# Altium schematic sheet Accelerometer.SchDoc(Accelerometer)
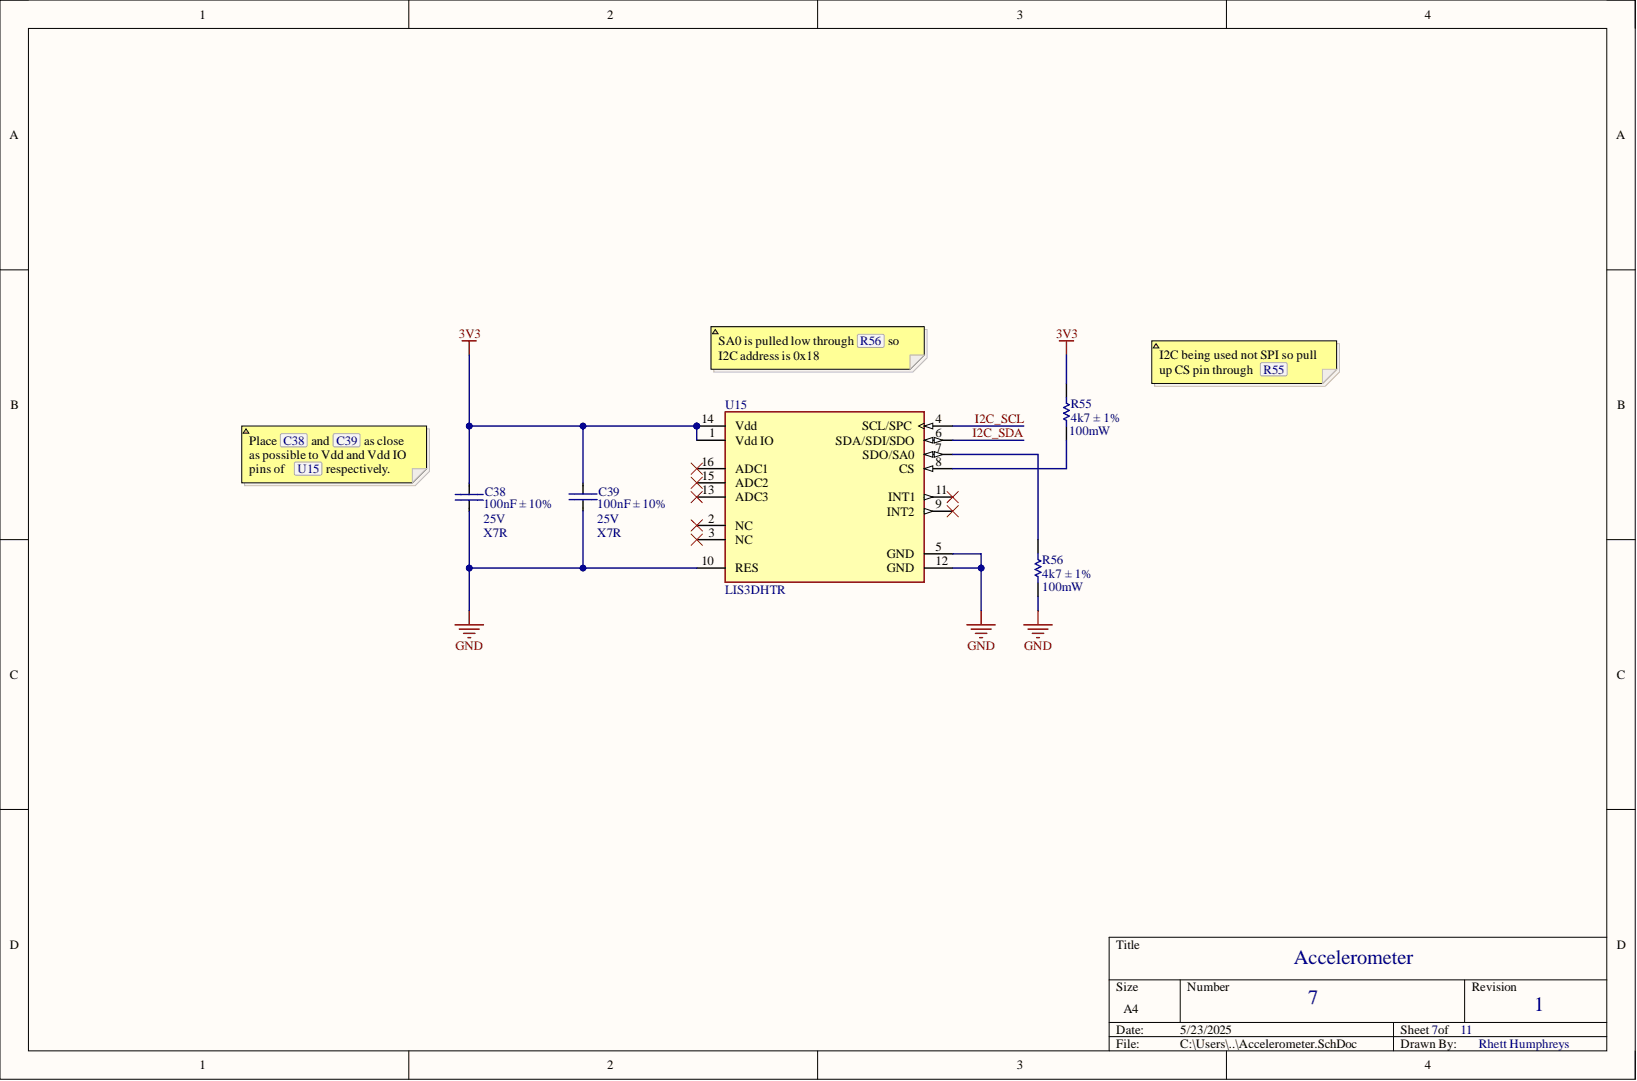
[diagram p0: Accelerometer.SchDoc]

## Parts
C38  100nF ± 10%  [CAP-0603]
C39  100nF ± 10%  [CAP-0603]
R55  4k7 ± 1%  [RESC1609X50X30NL8T20]
R56  4k7 ± 1%  [RESC1609X50X30NL8T20]
U15  LIS3DHTR  [FP-LGA-16-7983231-MFG]

## Nets
3V3: C38.2 C39.2 R55.2 U15.1(Vdd_IO) U15.14(Vdd)
GND: C38.1 C39.1 R56.1 U15.5(GND) U15.10(RES) U15.12(GND)
I2C_SCL: U15.4(SCL/SPC)
I2C_SDA: U15.6(SDA/SDI/SDO)
NetR55_1: R55.1 U15.8(CS)
NetR56_2: R56.2 U15.7(SDO/SA0)

Not connected: U15 2(NC) 3(NC) 9(INT2) 11(INT1) 13(ADC3) 15(ADC2) 16(ADC1)

## Library data of the parts
C38 C39 = CAP-0603: Capacitor chip unpolarized. 0603; Component Kind: Standard; Library Name: Master.SchLib
R55 R56 = CMP-1666-00005-4: Chip Resistor, 10 KOhm, +/- 1%, 100 mW, -55 to 155 degC, 0603 (1608 Metric), RoHS, Tape and Reel; Manufacturer: Vishay Semiconductor; Voltage Rating: 75 V; Component Kind: Standard; ComponentLink1Description: Manufacturer URL; ComponentLink1URL: http://www.vishay.com/; ComponentLink2Description: Datasheet; ComponentLink2URL: https://datasheet.ciiva.com/11809/0900766b80d98afc-11809037.pdf; DatasheetVersion: 06/2012; Height: 0.45 mm; Length: 1.55 mm; Library Name: Altium Content Vault; Max Operating Temperature: 155 degC; Min Operating Temperature: -55 degC; Mounting Technology: Surface Mount; Package Quantity: 5000; PackageDescription: 2-Pin Surface Mount Device, Body 1.575 x 0.85 mm; PackageReference: 0603; Packaging: Tape and Reel; REACH SVHC: Unknown; RoHSCompliant: true
U15 = CMP-0234-00055-6: ACCEL 2-16G I2C/SPI 16LGA; Axis: X, Y, Z; Bandwidth: 5kHz; Interface: I2C; Max Supply Voltage: 3.6V; Min Supply Voltage: 1.71V; Operating Supply Current: 11uA; Operating Supply Voltage: 2.5V; Output Type: Digital; Resolution: 16b; Sensor Type: 3 Axis; Case/Package: LGA; Component Kind: Standard; Contact Plating: Gold; Depth: 3mm; Height: 1mm; Lead Free: Lead Free; Length: 3mm; Library Name: Altium Content Vault; Max Operating Temperature: 85°C; Max Supply Voltage (DC): 3.6V; Min Operating Temperature: -40°C; Min Supply Voltage (DC): 1.71V; Number of Pins: 16; Package Quantity: 1; Packaging: Tape and Reel; Radiation Hardening: No; REACH SVHC: No SVHC; RoHS Compliant: Yes; Series: -; Width: 3mm
